# Altium schematic sheet 02_MCU.SchDoc
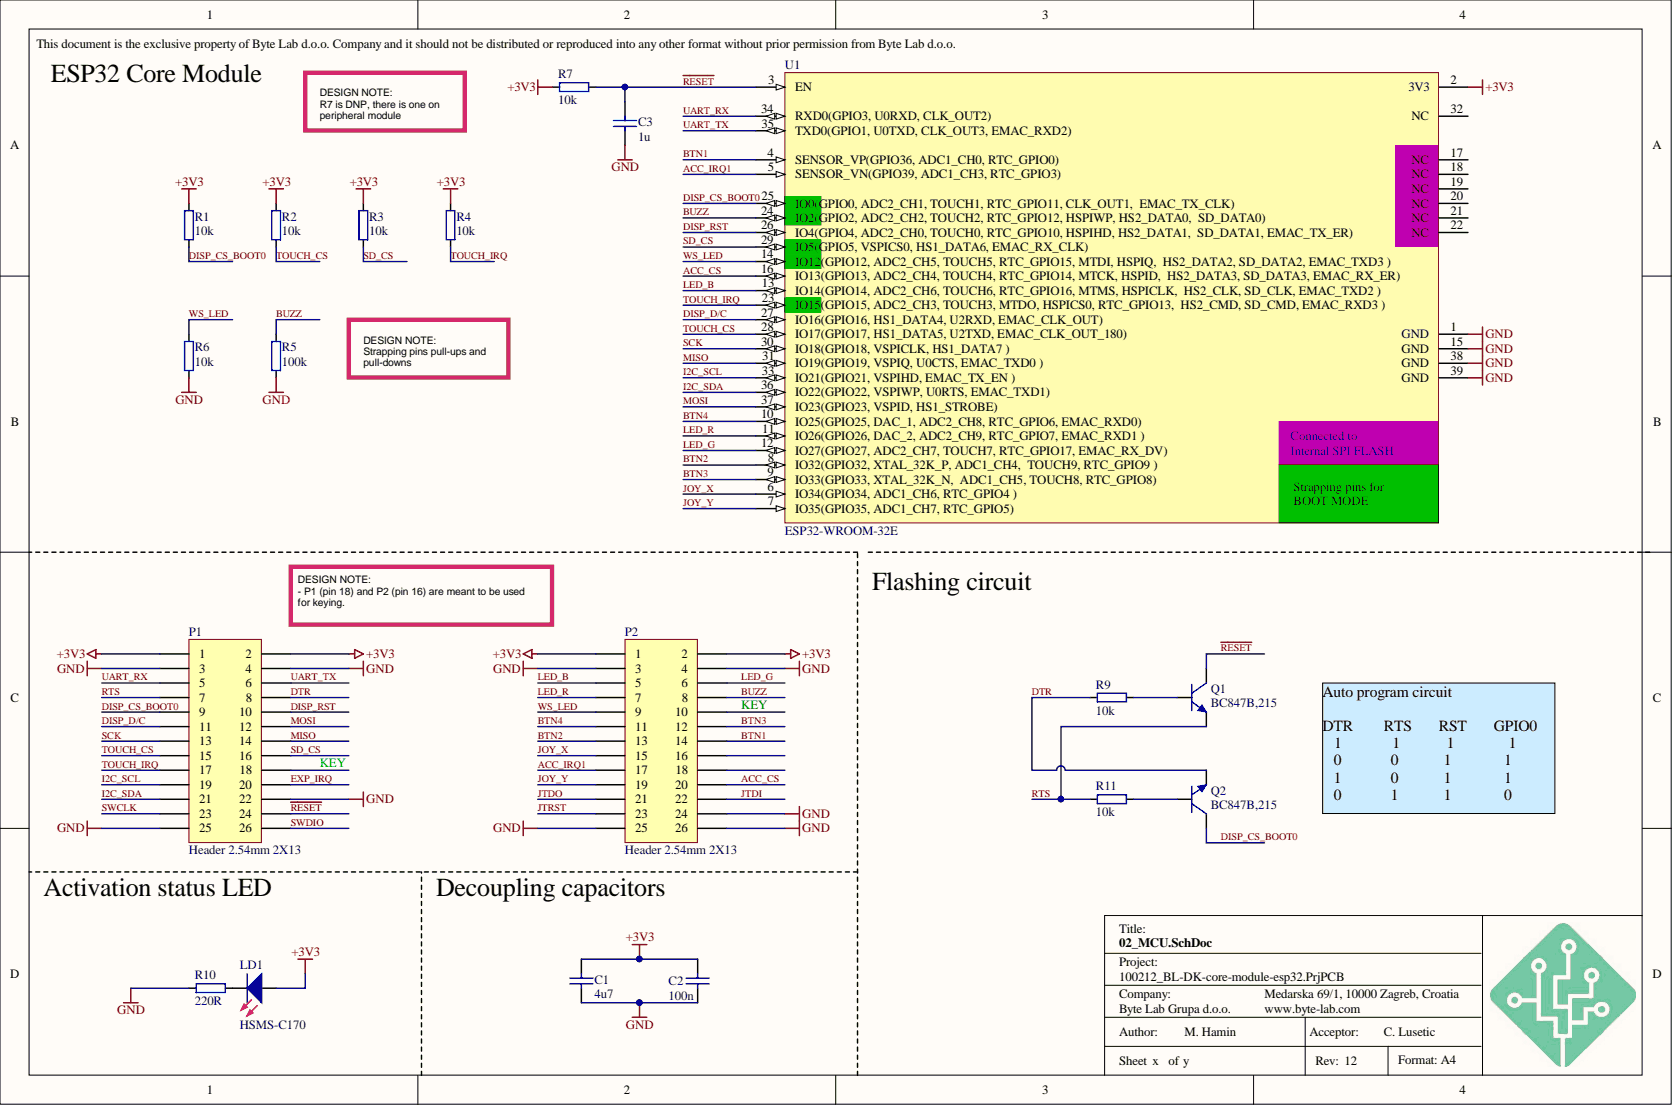
[diagram p0: 02_MCU.SchDoc]

## Parts
C1  4u7  [C-0805]
C2  100n  [C-0603]
C3  1u  [C-0603]
LD1  HSMS-C170  [LED-0805]
P1  Header 2.54mm 2X13  [Header 2.54mm 2X13]
P2  Header 2.54mm 2X13  [Header 2.54mm 2X13]
Q1  BC847B,215  [SOT-23]
Q2  BC847B,215  [SOT-23]
R1  10k  [R-0603]
R2  10k  [R-0603]
R3  10k  [R-0603]
R4  10k  [R-0603]
R5  100k  [R-0603]
R6  10k  [R-0603]
R7  10k  [R-0603]
R9  10k  [R-0603]
R10  220R  [R-0805]
R11  10k  [R-0603]
U1  ESP32-WROOM-32E  [ESP32-WROOM-32E_UE]

## Nets
+3V3: C1.2 C2.2 LD1.1 P1.1 P1.2 P2.1 P2.2 R1.2 R2.2 R3.2 R4.2 R7.2 U1.2(3V3)
GND: C1.1 C2.1 C3.1 P1.3 P1.4 P1.22 P1.25 P2.3 P2.4 P2.24 P2.25 P2.26 R5.1 R6.1 R10.1 U1.1(GND) U1.15(GND) U1.38(GND) U1.39(GND)
ACC_CS: P2.20 U1.16(IO13(GPIO13,_ADC2_CH4,_TOUCH4,_RTC_GPIO14,_MTCK,_HSPID,)
ACC_IRQ1: P2.17 U1.5(SENSOR_VN(GPIO39,_ADC1_CH3,_RTC_GPIO3))
BTN1: P2.14 U1.4(SENSOR_VP(GPIO36,_ADC1_CH0,_RTC_GPIO0))
BTN2: P2.13 U1.8(IO32(GPIO32,_XTAL_32K_P,_ADC1_CH4,)
BTN3: P2.12 U1.9(IO33(GPIO33,_XTAL_32K_N,)
BTN4: P2.11 U1.10(IO25(GPIO25,_DAC_1,_ADC2_CH8,_RTC_GPIO6,_EMAC_RXD0))
BUZZ: P2.8 R5.2 U1.24
DISP_CS_BOOT0: P1.9 Q2.3 R1.1 U1.25(IO0(GPIO0,_ADC2_CH1,_TOUCH1,_RTC_GPIO11,_CLK_OUT1,)
DISP_D/C: P1.11 U1.27(IO16(GPIO16,_HS1_DATA4,_U2RXD,_EMAC_CLK_OUT))
DISP_RST: P1.10 U1.26
DTR: P1.8 Q2.2 R9.1
EXP_IRQ: P1.20
I2C_SCL: P1.19 U1.33(IO21(GPIO21,_VSPIHD,_EMAC_TX_EN_))
I2C_SDA: P1.21 U1.36(IO22(GPIO22,_VSPIWP,_U0RTS,_EMAC_TXD1))
JOY_X: P2.15 U1.6(IO34(GPIO34,_ADC1_CH6,_RTC_GPIO4_))
JOY_Y: P2.19 U1.7(IO35(GPIO35,_ADC1_CH7,_RTC_GPIO5))
JTDI: P2.22
JTDO: P2.21
JTRST: P2.23
LED_B: P2.5 U1.13(IO14(GPIO14,_ADC2_CH6,_TOUCH6,_RTC_GPIO16,_MTMS,_HSPICLK,)
LED_G: P2.6 U1.12(IO27(GPIO27,_ADC2_CH7,_TOUCH7,_RTC_GPIO17,_EMAC_RX_DV))
LED_R: P2.7 U1.11(IO26(GPIO26,_DAC_2,_ADC2_CH9,_RTC_GPIO7,_EMAC_RXD1_))
MISO: P1.14 U1.31(IO19(GPIO19,_VSPIQ,_U0CTS,_EMAC_TXD0_))
MOSI: P1.12 U1.37(IO23(GPIO23,_VSPID,_HS1_STROBE))
~{RESET}: C3.2 P1.24 Q1.3 R7.1 U1.3(EN)
RTS: P1.7 Q1.2 R11.1
SCK: P1.13 U1.30(IO18(GPIO18,_VSPICLK,_HS1_DATA7_))
SD_CS: P1.16 R3.1 U1.29(IO5(GPIO5,_VSPICS0,_HS1_DATA6,_EMAC_RX_CLK))
SWCLK: P1.23
SWDIO: P1.26
TOUCH_CS: P1.15 R2.1 U1.28(IO17(GPIO17,_HS1_DATA5,_U2TXD,_EMAC_CLK_OUT_180))
TOUCH_IRQ: P1.17 R4.1 U1.23(IO15(GPIO15,_ADC2_CH3,_TOUCH3,_MTDO,_HSPICS0,_RTC_GPIO13,)
UART_RX: P1.5 U1.34(RXD0(GPIO3,_U0RXD,_CLK_OUT2))
UART_TX: P1.6 U1.35(TXD0(GPIO1,_U0TXD,_CLK_OUT3,_EMAC_RXD2))
WS_LED: P2.9 R6.2 U1.14(IO12(GPIO12,_ADC2_CH5,_TOUCH5,_RTC_GPIO15,_MTDI,_HSPIQ,)
NetLD1_2: LD1.2 R10.2
NetQ1_1: Q1.1 R9.2
NetQ2_1: Q2.1 R11.2

Not connected: P1 18; P2 10 16 18; U1 17(NC) 18(NC) 19(NC) 20(NC) 21(NC) 22(NC) 32(NC)

## Library data of the parts
C1 = C0805-4u7-10-X7R: Capacitor ceramic 4u7 ±10% X7R 10V 0805; BL Part Number: 2000-532; Checked_By: Dodig; Drawn_By: Puskar; Component Kind: Standard; DateModified: 03-12-2013; Library Name: BL_Passive.SchLib; Manufacturer: N/A; Manufacturer Part Number: N/A; Simulation: CAP
C2 = C0603-100n-50V-X7R: Capacitor ceramic 100n X7R 50V 0603; BL Part Number: 2000-637; Checked_By: Puskar; Drawn_By: Dodig; Component Kind: Standard; DateModified: 14-06-2013; Library Name: BL_Passive.SchLib; Manufacturer: N/A; Manufacturer Part Number: N/A; Signal Integrity: C0603; Simulation: CAP; Supplier 1: Digi-Key; Supplier Part Number 1: 490-4779-1-ND
C3 = C0603-1u-16V-X7R: Capacitor ceramic 1u ±10% X7R 16V 0603; BL Part Number: 2002-492; Manufacturer: Samsung; Manufacturer Part Number: CL10B105KO8NNNC; Checked_By: Puskar; Drawn_By: Dodig; Component Kind: Standard; DateModified: 17-06-2015; Library Name: BL_Passive.SchLib; Signal Integrity: C0603; Simulation: CAP; Supplier 1: Digi-Key; Supplier Part Number 1: 1276-1019-1-ND
LD1 = LED HSMS-C170: LED RED DIFFUSED 0805 SMD; BL Part Number: 2002-870; Manufacturer: Broadcom Limited; Checked_By: Hamin; Drawn_By: Zvonc; Component Kind: Standard; DateModified: 17-04-2018; Library Name: BL_Active.SchLib; Manufacturer Part Number: HSMS-C170; Supplier 1: Digi-Key; Supplier Part Number 1: 516-1429-2-ND
P1 P2 = Conn Header 2.54mm 2X13: 2.54mm pitch 26 pin (2x13) top entry wire to board connector THT; BL Part Number: 2001-845; Checked_By: Dodig; Drawn_By: Zvonc; Note: Made from 2000-696; Component Kind: Standard; DateModified: 07-10-2014; Library Name: BL_Mechanical.SchLib; Manufacturer: N/A; Manufacturer Part Number: N/A
Q1 Q2 = BC847B: Transistor NPN 100mA 45V SOT-23; BL Part Number: 2001-717; Manufacturer: NXP; Checked_By: Puskar; Drawn_By: Dodig; Component Kind: Standard; DateModified: 15-06-2014; Library Name: BL_Active.SchLib; Manufacturer Part Number: BC847B,215; Supplier 1: Digi-Key; Supplier Part Number 1: 568-1633-1-ND
R1-R4 R6 R7 R9 R11 = R0603-10k-1: Resistor 10k ±1% 0603; BL Part Number: 2000-642; Checked_By: Puskar; Drawn_By: Dodig; Component Kind: Standard; DateModified: 17-06-2013; Library Name: BL_Passive.SchLib; Manufacturer: N/A; Manufacturer Part Number: N/A; Supplier 1: Digi-Key; Supplier Part Number 1: 311-10.0KHRCT-ND
R5 = R0603-100k-1: Resistor 100k ±1% 0603; BL Part Number: 2000-635; Checked_By: Puskar; Drawn_By: Dodig; Component Kind: Standard; DateModified: 14-06-2013; Library Name: BL_Passive.SchLib; Manufacturer: N/A; Manufacturer Part Number: N/A; Supplier 1: Digi-Key; Supplier Part Number 1: 311-100KHRCT-ND
R10 = R0805-220R-1: Resistor 220R ±1% 0805; BL Part Number: 2005-154; Checked_By: Hlupic; Drawn_By: Fumic; Component Kind: Standard; DateModified: 24-06-2020; Library Name: BL_Passive.SchLib; Manufacturer: N/A; Manufacturer Part Number: N/A
U1 = ESP32-WROOM-32E: WI-FI, BLE Chipset module ESP32-WROOM-32E; BL Part Number: 2005-935; Manufacturer: Espressif Systems; Checked_By: Fumic; Drawn_By: Hamin; Component Kind: Standard; DateModified: 17-06-2021; Library Name: BL_Digital.SchLib; Manufacturer Part Number: ESP32-WROOM-32E; Supplier 1: Digi-Key; Supplier Part Number 1: 1965-ESP32-WROOM-32E(4MB)CT-ND
